# Altium schematic sheet Robot_Sheet1.SchDoc
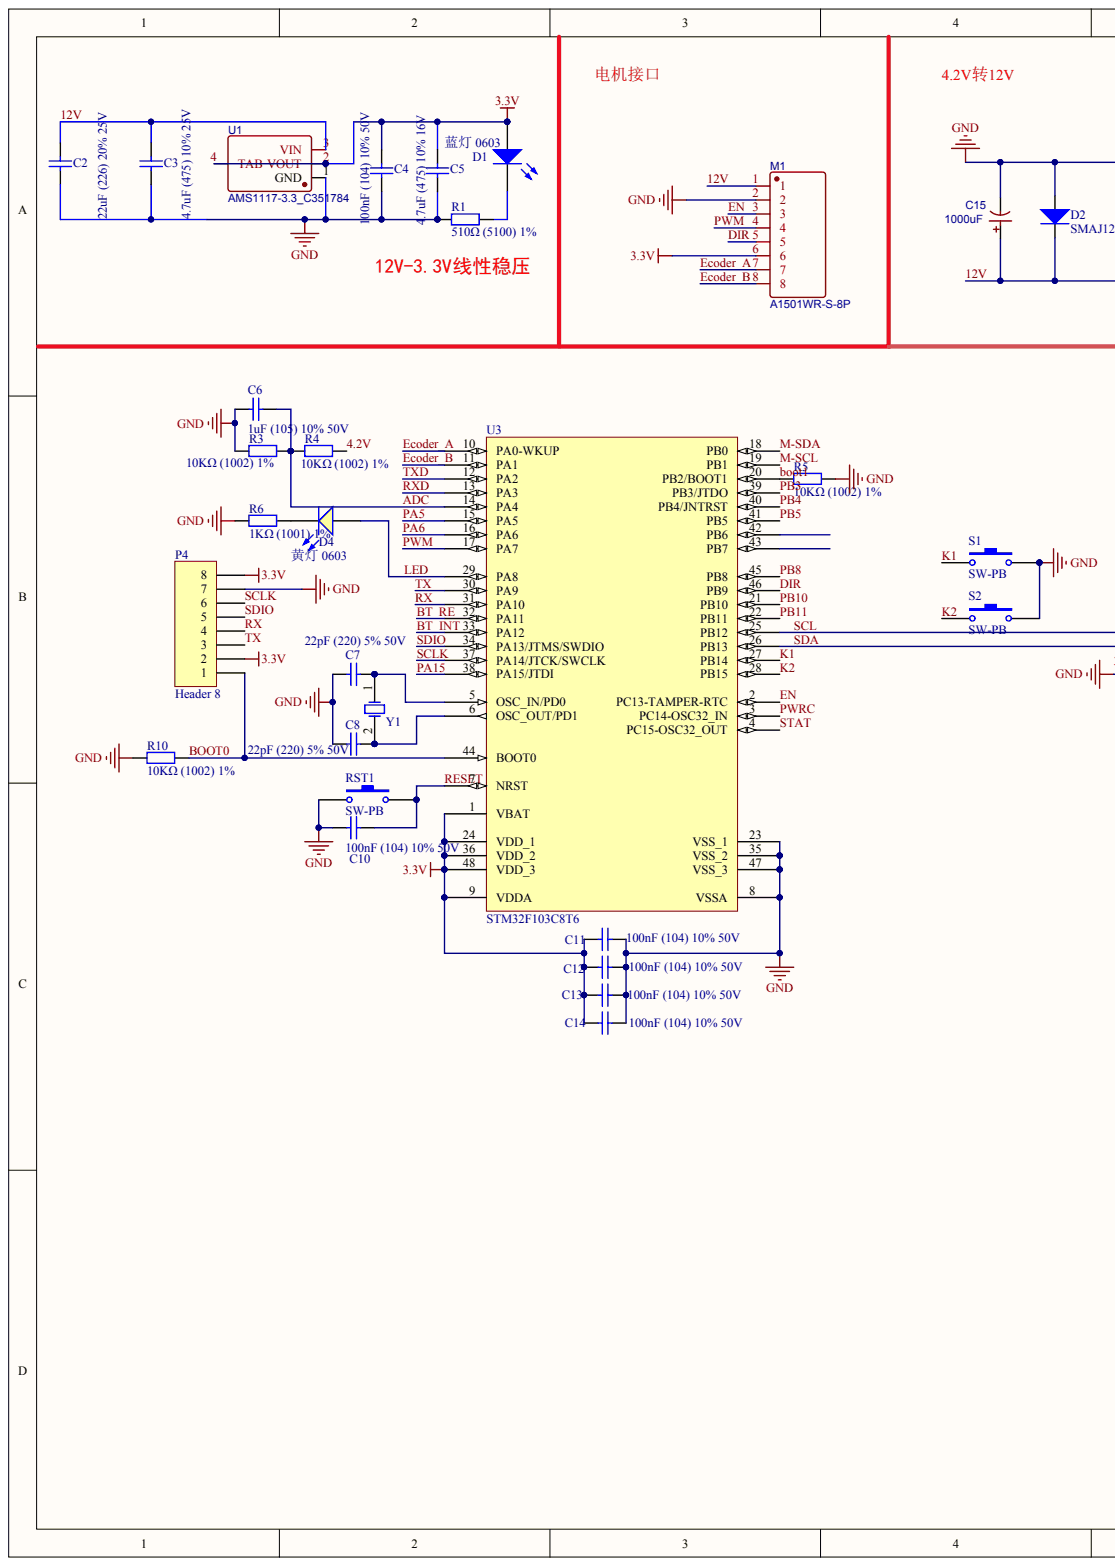
[diagram p0: Robot_Sheet1.SchDoc, left]
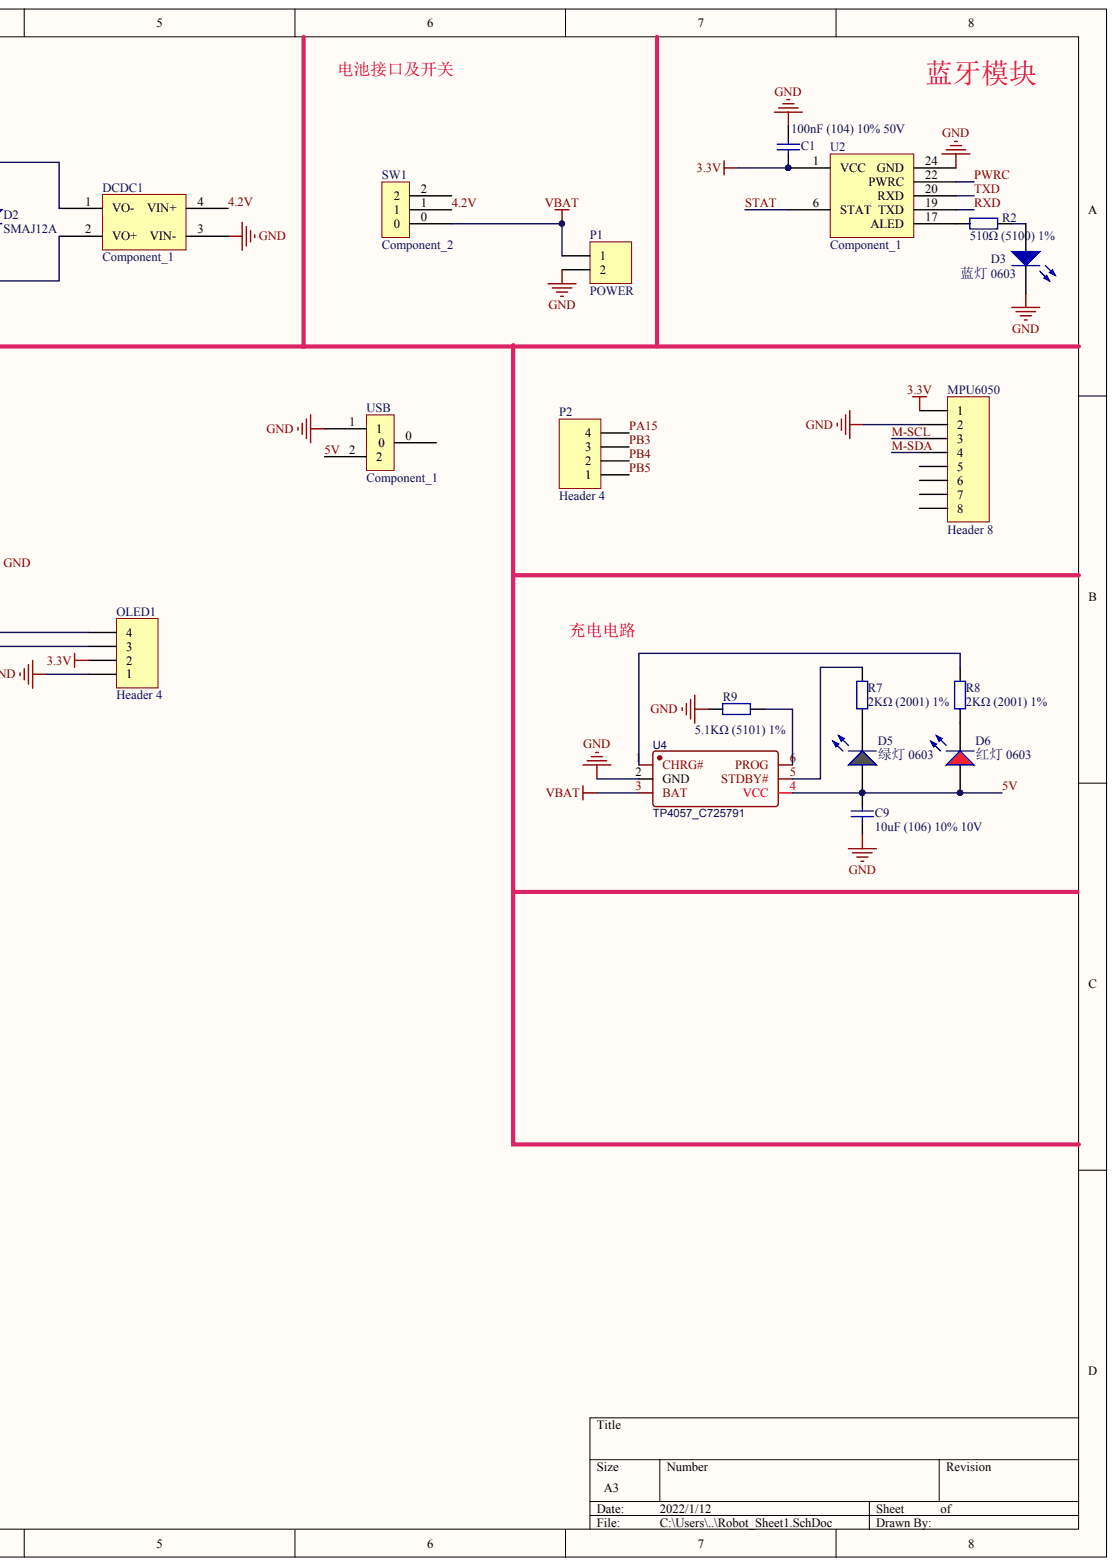
[diagram p1: Robot_Sheet1.SchDoc, right]

## Parts
C1  100nF (104) 10% 50V
C2  22uF (226) 20% 25V
C3  4.7uF (475) 10% 25V
C4  100nF (104) 10% 50V
C5  4.7uF (475) 10% 16V
C6  1uF (105) 10% 50V
C7  22pF (220) 5% 50V
C8  22pF (220) 5% 50V
C9  10uF (106) 10% 10V
C10  100nF (104) 10% 50V
C11  100nF (104) 10% 50V
C12  100nF (104) 10% 50V
C13  100nF (104) 10% 50V
C14  100nF (104) 10% 50V
C15  1000uF
D1  蓝灯 0603  Package: 0603
D2  Type: SMAJ12A  (hidden comment: TVS)
D3  蓝灯 0603  Package: 0603
D4  黄灯 0603  Package: 0603
D5  绿灯 0603
D6  红灯 0603  Package: 0603
DCDC1  Component_1
M1  A1501WR-S-8P
MPU6050  Header 8
OLED1  Header 4
P1  POWER
P2  Header 4
P4  Header 8
R1  510Ω (5100) ±1%
R2  510Ω (5100) ±1%
R3  10KΩ (1002) ±1%
R4  10KΩ (1002) ±1%
R5  10KΩ (1002) ±1%
R6  1KΩ (1001) ±1%
R7  2KΩ (2001) ±1%  (value not drawn)
R8  2KΩ (2001) ±1%  (value not drawn)
R9  5.1KΩ (5101) ±1%
R10  10KΩ (1002) ±1%
RST1  SW-PB
S1  SW-PB
S2  SW-PB
SW1  Component_2
U1  AMS1117-3.3_C351784
U2  Component_1
U3  STM32F103C8T6
U4  TP4057_C725791
USB  Component_1
Y1  8MHz ±20ppm 20pF  (value not drawn)

## Nets
3.3V: C1.1 C4.2 C5.2 C11.1 C12.1 C13.1 C14.1 D1.A M1.6 MPU6050.1 OLED1.2 P4.2 P4.8 U1.2(VOUT) U1.4(TAB) U2.1(VCC) U3.1(VBAT) U3.9(VDDA) U3.24(VDD_1) U3.36(VDD_2) U3.48(VDD_3)
GND: C1.2 C2.1 C3.1 C4.1 C5.1 C6.1 C7.1 C8.1 C9.2 C10.1 C11.2 C12.2 C13.2 C14.2 C15.2 D2.1 D3.K DCDC1.1(VO-) DCDC1.3(VIN-) M1.2 MPU6050.2 OLED1.1 P1.2 P4.7 R1.1 R3.1 R5.2 R6.1 R9.1 R10.1 RST1.1 S1.2 S2.2 U1.1(GND) U2.24(GND) U3.8(VSSA) U3.23(VSS_1) U3.35(VSS_2) U3.47(VSS_3) U4.2(GND) USB.1
VBAT: P1.1 SW1.0 U4.3(BAT)
4.2V: DCDC1.4(VIN+) R4.2 SW1.1
5V: C9.1 D5.A D6.A U4.4(VCC) USB.2
12V: C2.2 C3.2 C15.1 D2.2 DCDC1.2(VO+) M1.1 U1.3(VIN)
ADC: C6.2 R3.2 R4.1 U3.14(PA4)
BOOT0: P4.1 R10.2 U3.44(BOOT0)
BOOT1: R5.1 U3.20(PB2/BOOT1)
BT_INT: U3.33(PA12)
BT_RE: U3.32(PA11)
DIR: M1.5 U3.46(PB9)
ECODER_A: M1.7 U3.10(PA0-WKUP)
ECODER_B: M1.8 U3.11(PA1)
EN: M1.3 U3.2(PC13-TAMPER-RTC)
K1: S1.1 U3.27(PB14)
K2: S2.1 U3.28(PB15)
LED: D4.A U3.29(PA8)
M-SCL: MPU6050.3 U3.19(PB1)
M-SDA: MPU6050.4 U3.18(PB0)
PA5: U3.15(PA5)
PA6: U3.16(PA6)
PA15: P2.4 U3.38(PA15/JTDI)
PB3: P2.3 U3.39(PB3/JTDO)
PB4: P2.2 U3.40(PB4/JNTRST)
PB5: P2.1 U3.41(PB5)
PB8: U3.45(PB8)
PB10: U3.21(PB10)
PB11: U3.22(PB11)
PWM: M1.4 U3.17(PA7)
PWRC: U2.22(PWRC) U3.3(PC14-OSC32_IN)
RESET: C10.2 RST1.2 U3.7(NRST)
RX: P4.4 U3.31(PA10)
RXD: U2.19(TXD) U3.13(PA3)
SCL: OLED1.4 U3.25(PB12)
SCLK: P4.6 U3.37(PA14/JTCK/SWCLK)
SDA: OLED1.3 U3.26(PB13)
SDIO: P4.5 U3.34(PA13/JTMS/SWDIO)
STAT: U2.6(STAT) U3.4(PC15-OSC32_OUT)
TX: P4.3 U3.30(PA9)
TXD: U2.20(RXD) U3.12(PA2)
NetC7_2: C7.2 U3.5(OSC_IN/PD0) Y1.1
NetC8_2: C8.2 U3.6(OSC_OUT/PD1) Y1.2
NetD1_K: D1.K R1.2
NetD3_A: D3.A R2.2
NetD4_K: D4.K R6.2
NetD5_K: D5.K R7.1
NetD6_K: D6.K R8.1
NetR2_1: R2.1 U2.17(ALED)
NetR7_2: R7.2 U4.5(STDBY#)
NetR8_2: R8.2 U4.1(CHRG#)
NetR9_2: R9.2 U4.6(PROG)

Not connected: MPU6050 5 6 7 8; SW1 2; U3 42(PB6) 43(PB7); USB 0

## Library data of the parts
C15 = (no symbol name): BOM_Manufacturer: ValuePro; BOM_Manufacturer Part: 1000uF 35V 13*20; nameAlias: Capacitance; BOM_Supplier: LCSC; BOM_Supplier Part: C10749; spicePre: C; spiceSymbolName: 1000uF 35V 13*20
M1 = (no symbol name): BOM_Manufacturer: Changjiang Connectors; Contributor: LCSC; BOM_Supplier: LCSC; BOM_Supplier Part: C225173; BOM_Manufacturer Part: A1501WR-S-8P; spicePre: C; spiceSymbolName: A1501WR-S-8P
U1 = (no symbol name): BOM_Manufacturer: PUOLOP; Contributor: LCSC; BOM_Supplier: LCSC; BOM_Supplier Part: C351784; BOM_Manufacturer Part: AMS1117-3.3; BOM_JLCPCB Part Class: Extended Part; spicePre: U; spiceSymbolName: AMS1117-3.3_C351784
U4 = (no symbol name): BOM_Manufacturer: UMW(Youtai Semiconductor Co., Ltd.); BOM_Manufacturer Part: TP4057; Contributor: LCSC; BOM_Supplier: LCSC; BOM_Supplier Part: C725791; spicePre: U; spiceSymbolName: TP4057_C725791
C1-C14 D1-D6 DCDC1 MPU6050 OLED1 P1 P2 P4 R1-R10 RST1 S1 S2 SW1 U2 U3 USB Y1 = (no symbol name)
  C1 C4 C10-C14: ComponentLink1Description: 供应商链接; ComponentLink1URL: http://www.szlcsc.com/product/details_15331.html; Package: 0603; Supplier: LC; SuppliersPartNumber: C14663
  C2: ComponentLink1Description: 供应商链接; ComponentLink1URL: http://www.szlcsc.com/product/details_46786.html; Package: 0805; Supplier: LC; SuppliersPartNumber: C45783
  C3: ComponentLink1Description: 供应商链接; ComponentLink1URL: http://www.szlcsc.com/product/details_2131.html; Package: 0805; Supplier: LC; SuppliersPartNumber: C1779
  C5: ComponentLink1Description: 供应商链接; ComponentLink1URL: http://www.szlcsc.com/product/details_20375.html; Package: 0603; Supplier: LC; SuppliersPartNumber: C19666
  C6: ComponentLink1Description: 供应商链接; ComponentLink1URL: http://www.szlcsc.com/product/details_16531.html; Package: 0603; Supplier: LC; SuppliersPartNumber: C15849
  C7 C8: ComponentLink1Description: 供应商链接; ComponentLink1URL: http://www.szlcsc.com/product/details_2005.html; Package: 0603; Supplier: LC; SuppliersPartNumber: C1653
  C9: ComponentLink1Description: 供应商链接; ComponentLink1URL: http://www.szlcsc.com/product/details_20411.html; Package: 0603; Supplier: LC; SuppliersPartNumber: C19702
  D1 D3: ComponentLink1Description: 供应商链接; ComponentLink1URL: http://www.szlcsc.com/product/details_73150.html; Supplier: LC; SuppliersPartNumber: C72041
  D2: Published: 8-Jun-2000; LatestRevisionDate: 17-Jul-2002; LatestRevisionNote: Re-released for DXP Platform.; PackageDocument: Sep-1996; PackageReference: SMC; Code_JEDEC: DO-214-AB
  D4: ComponentLink1Description: 供应商链接; ComponentLink1URL: http://www.szlcsc.com/product/details_73147.html; Supplier: LC; SuppliersPartNumber: C72038
  D5: ComponentLink1Description: 供应商链接; ComponentLink1URL: http://www.szlcsc.com/product/details_73152.html; Package: 0603; Supplier: LC; SuppliersPartNumber: C72043
  D6: ComponentLink1Description: 供应商链接; ComponentLink1URL: http://www.szlcsc.com/product/details_2659.html; Supplier: LC; SuppliersPartNumber: C2286
  MPU6050 OLED1 P1 P2 P4: LatestRevisionDate: 17-Jul-2002; LatestRevisionNote: Re-released for DXP Platform.
  R1 R2: ComponentLink1Description: 供应商链接; ComponentLink1URL: http://www.szlcsc.com/product/details_23920.html; Package: 0603; Supplier: LC; SuppliersPartNumber: C23193
  R3-R5 R10: ComponentLink1Description: 供应商链接; ComponentLink1URL: http://www.szlcsc.com/product/details_26547.html; Package: 0603; Supplier: LC; SuppliersPartNumber: C25804
  R6: ComponentLink1Description: 供应商链接; ComponentLink1URL: http://www.szlcsc.com/product/details_21904.html; Package: 0603; Supplier: LC; SuppliersPartNumber: C21190
  R7 R8: ComponentLink1Description: 供应商链接; ComponentLink1URL: http://www.szlcsc.com/product/details_23702.html; Package: 0603; Supplier: LC; SuppliersPartNumber: C22975
  R9: ComponentLink1Description: 供应商链接; ComponentLink1URL: http://www.szlcsc.com/product/details_23913.html; Package: 0603; Supplier: LC; SuppliersPartNumber: C23186
  RST1 S1 S2: Published: 24-Mar-1999; LatestRevisionDate: 17-Jul-2002; LatestRevisionNote: Re-released for DXP Platform.; PackageReference: SPST-2
  U3: Published: 30-May-2008; DatasheetVersion: rev6, Mar-2008; PackageVersion: Mar-2008; PackageReference: LQFP48; PackageDescription: 48-Pin Low-Profile Quad Flat Pack, 7 x 7 mm Body, 0.5 mm Pitch (LQFP48); ComponentLink1Description: Manufacturer Link; ComponentLink1URL: www.st.com/stm32; ComponentLink2Description: Datasheet; ComponentLink2URL: http://www.st.com/stonline/products/literature/ds/13587.pdf
  Y1: ComponentLink1Description: 供应商链接; ComponentLink1URL: http://www.szlcsc.com/product/details_117214.html; Package: SMD-5032_2P; Supplier: LC; SuppliersPartNumber: C115962
  D2 MPU6050 OLED1 P1 P2 P4 RST1 S1 S2 U3: Publisher: Altium Limited
